# Altium schematic sheet LED Driver.SchDoc("LED Driver")
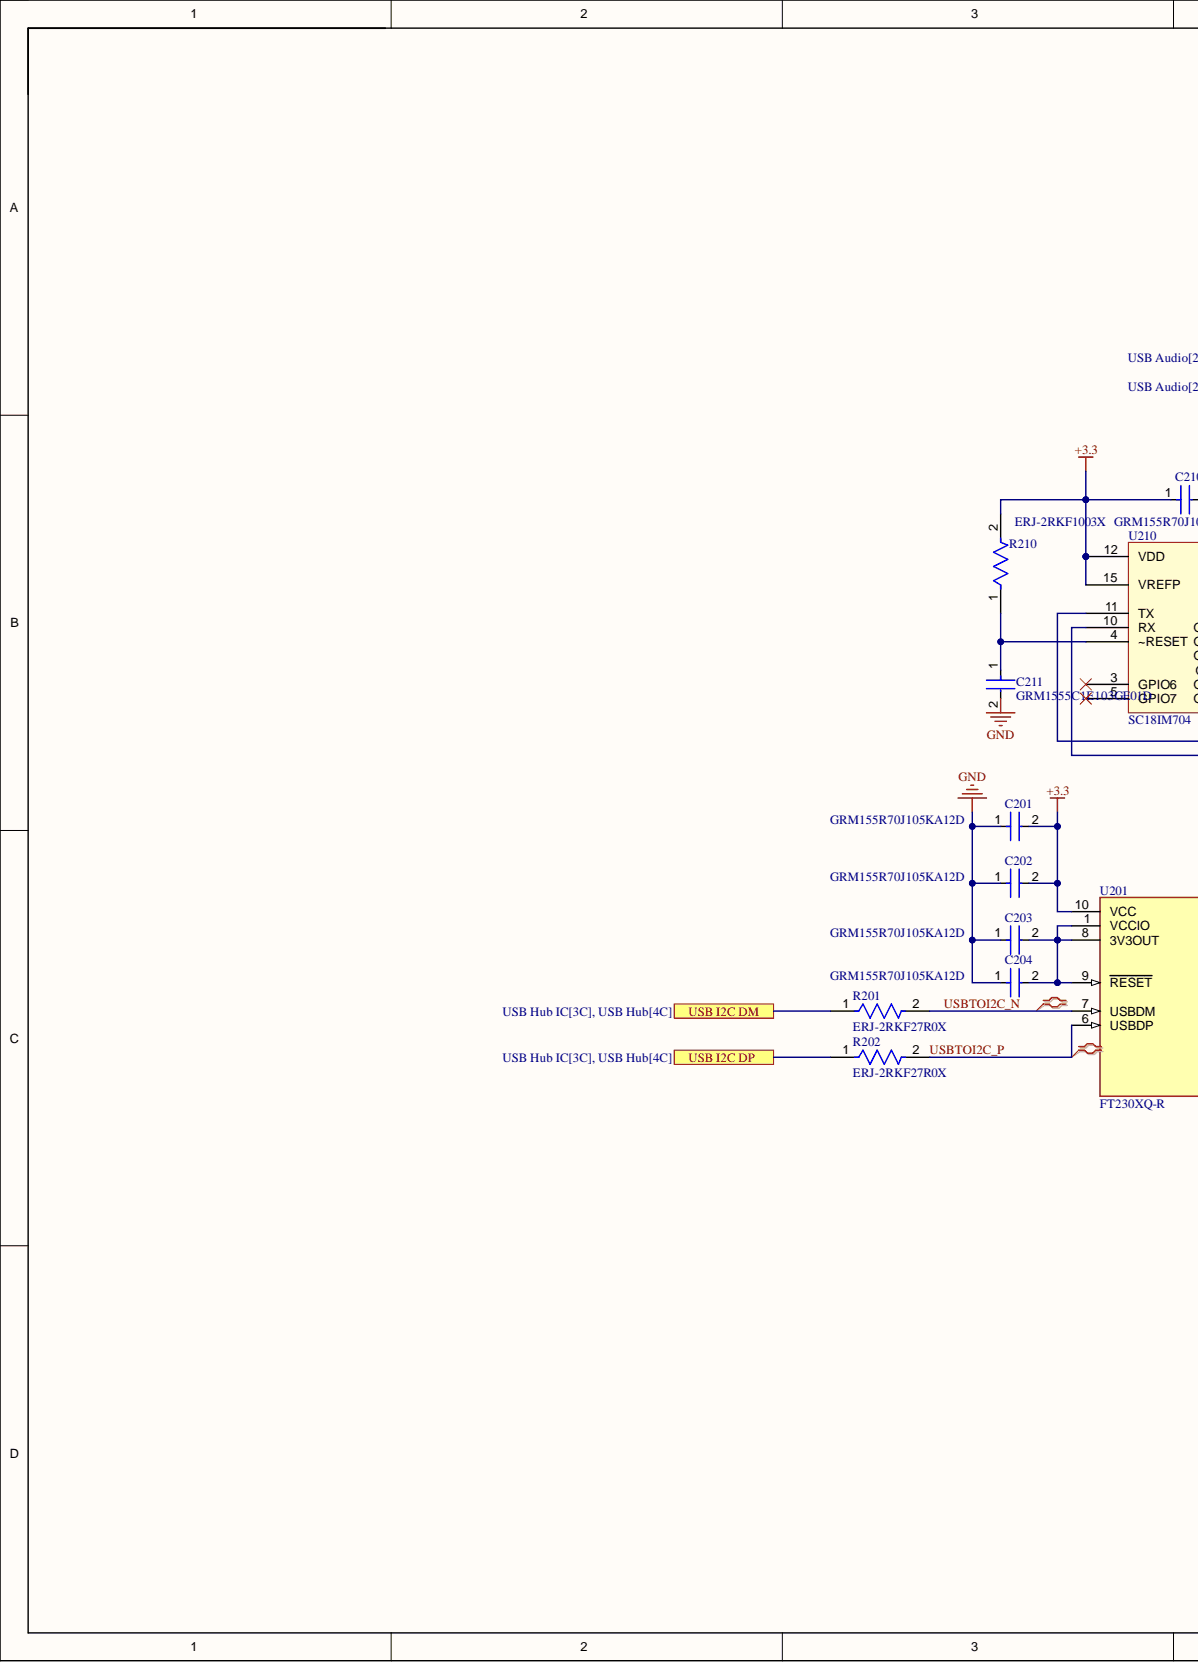
[diagram p0: LED Driver.SchDoc, left]
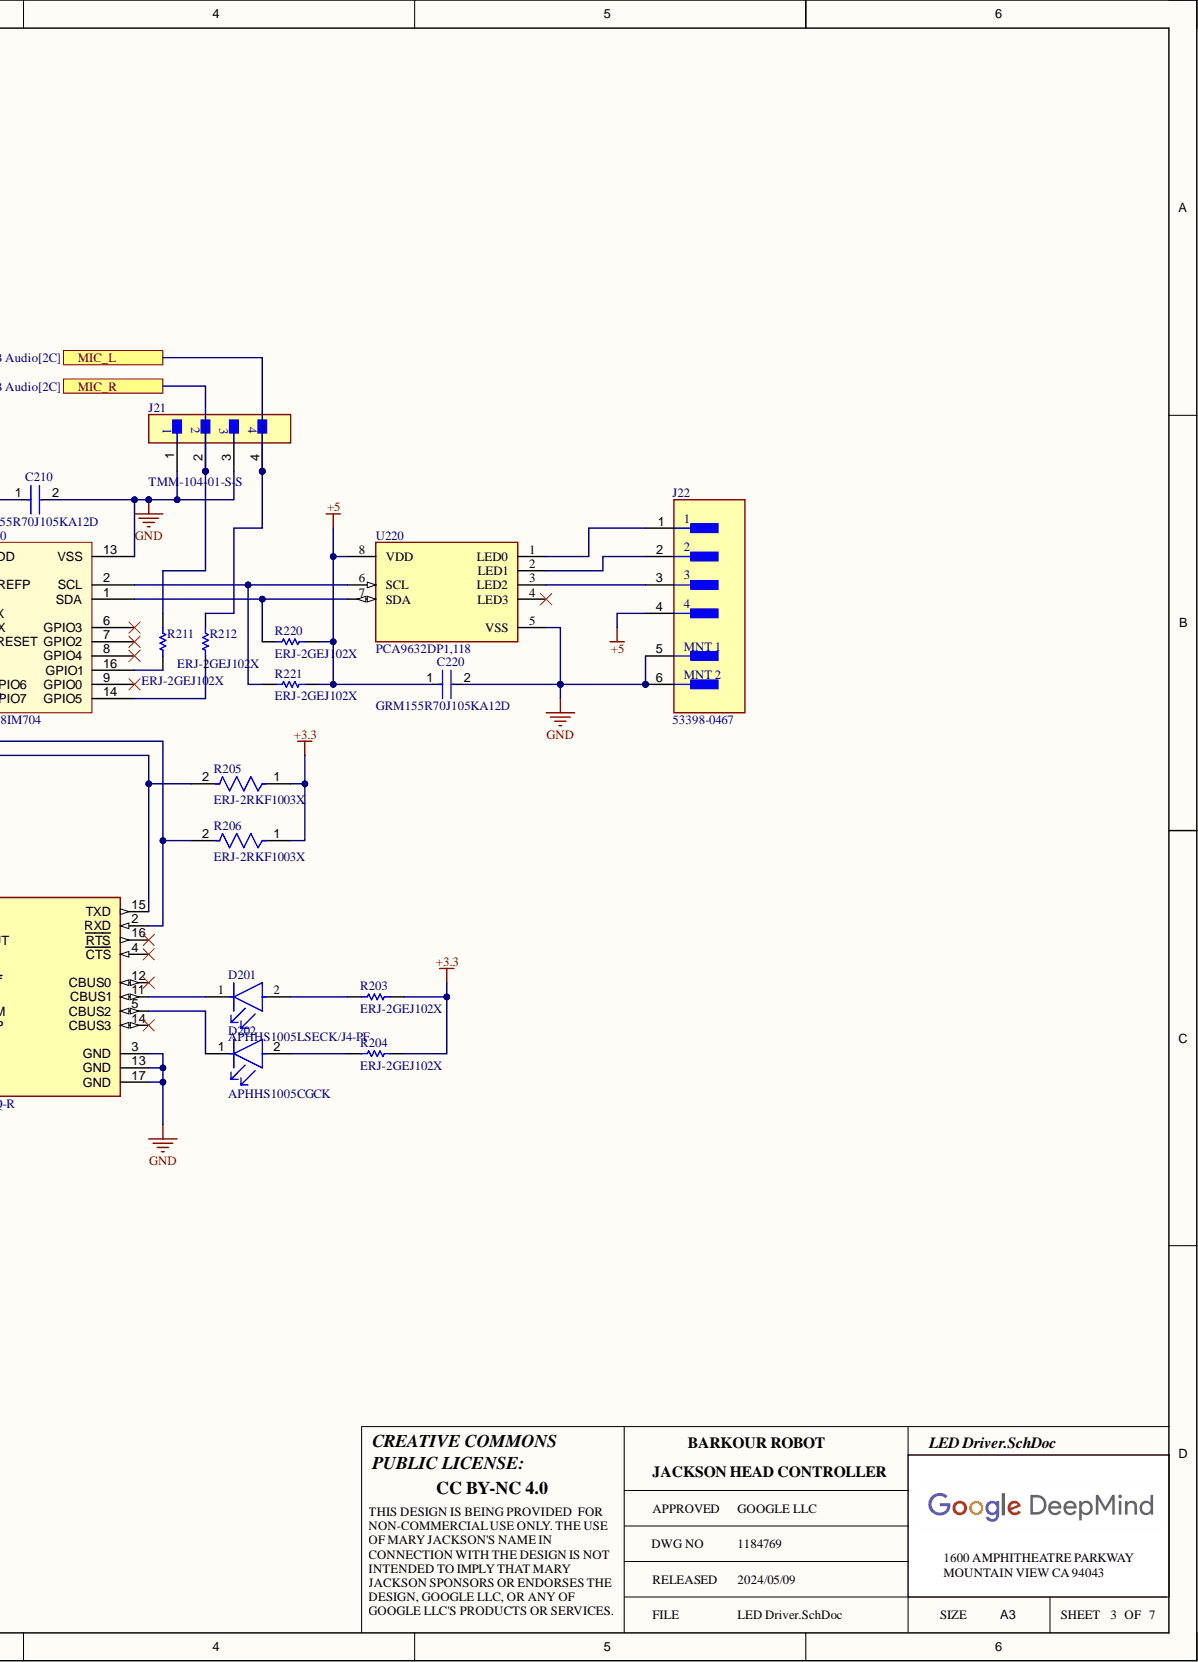
[diagram p1: LED Driver.SchDoc, right]

## Parts
C201  GRM155R70J105KA12D  [FP-GRM155-0_05-MFG]
C202  GRM155R70J105KA12D  [FP-GRM155-0_05-MFG]
C203  GRM155R70J105KA12D  [FP-GRM155-0_05-MFG]
C204  GRM155R70J105KA12D  [FP-GRM155-0_05-MFG]
C210  GRM155R70J105KA12D  [FP-GRM155-0_05-MFG]
C211  GRM1555C1E103GE01D  [FP-GRM155-0_15-IPC_B]
C220  GRM155R70J105KA12D  [FP-GRM155-0_05-MFG]
D201  APHHS1005LSECK/J4-PF  [FP-APHHS1005LSECK-J4-PF-MFG]
D202  APHHS1005CGCK  [FP-APHHS1005CGCK-MFG]
J21  TMM-104-01-S-S  [FP-TMM-104-01-S-S-MFG]
J22  53398-0467  [FP-53398-0467-MFG]
R201  ERJ-2RKF27R0X  [FP-ERJ2R-IPC_B]
R202  ERJ-2RKF27R0X  [FP-ERJ2R-IPC_B]
R203  ERJ-2GEJ102X  [RESC1005X40X25NL05T05]
R204  ERJ-2GEJ102X  [RESC1005X40X25NL05T05]
R205  ERJ-2RKF1003X  [FP-ERJ2RK-IPC_C]
R206  ERJ-2RKF1003X  [FP-ERJ2RK-IPC_C]
R210  ERJ-2RKF1003X  [FP-ERJ2RK-IPC_C]
R211  ERJ-2GEJ102X  [RESC1005X40X25NL05T05]
R212  ERJ-2GEJ102X  [RESC1005X40X25NL05T05]
R220  ERJ-2GEJ102X  [RESC1005X40X25NL05T05]
R221  ERJ-2GEJ102X  [RESC1005X40X25NL05T05]
U201  FT230XQ-R  [FP-QFN-16-MFG]
U210  SC18IM704  [TSSOP-16]
U220  PCA9632DP1,118  [FP-SOT505-1-IPC_C]

## Ports
MIC_L, MIC_R, USB I2C DM, USB I2C DP

## Nets
+3.3: C201.2 C202.2 C210.1 R203.2 R204.2 R205.1 R206.1 R210.2 U201.10(VCC) U210.12(VDD) U210.15(VREFP)
+5: C220.1 J22.4 R220.2 R221.2 U220.8(VDD)
GND: C201.1 C202.1 C203.1 C204.1 C210.2 C211.2 C220.2 J21.1 J21.3 J22.5 J22.6 U201.3(GND) U201.13(GND) U201.17(GND) U210.13(VSS) U220.5(VSS)
MIC_L: J21.4 R212.2 ; port MIC_L
MIC_R: J21.2 R211.2 ; port MIC_R
USB I2C DM: R201.1 ; port USB I2C DM
USB I2C DP: R202.1 ; port USB I2C DP
USBTOI2C_N: R201.2 U201.7(USBDM)
USBTOI2C_P: R202.2 U201.6(USBDP)
NetC203_2: C203.2 C204.2 U201.1(VCCIO) U201.8(3V3OUT) U201.9(RESET)
NetC211_1: C211.1 R210.1 U210.4(~RESET)
NetD201_1: D201.1 U201.11(CBUS1)
NetD201_2: D201.2 R203.1
NetD202_1: D202.1 U201.5(CBUS2)
NetD202_2: D202.2 R204.1
NetJ22_1: J22.1 U220.1(LED0)
NetJ22_2: J22.2 U220.2(LED1)
NetJ22_3: J22.3 U220.3(LED2)
NetR205_2: R205.2 U201.15(TXD) U210.10(RX)
NetR206_2: R206.2 U201.2(RXD) U210.11(TX)
NetR211_1: R211.1 U210.16(GPIO1)
NetR212_1: R212.1 U210.14(GPIO5)
NetR220_1: R220.1 U210.1(SDA) U220.7(SDA)
NetR221_1: R221.1 U210.2(SCL) U220.6(SCL)

Not connected: U201 4(CTS) 12(CBUS0) 14(CBUS3) 16(RTS); U210 3(GPIO6) 5(GPIO7) 6(GPIO3) 7(GPIO2) 8(GPIO4) 9(GPIO0); U220 4(LED3)

## Library data of the parts
C201-C204 C210 C220 = CMP-2008-04587-2: Chip Multilayer Ceramic Capacitors for General Purpose, 0402, 1.0uF, X7R, 15%, 10%, 6.3V; Capacitance: 1uF; Case Code (Imperial): 0402; Case Code (Metric): 1005; Case/Package: 0402; Component Kind: Standard; Depth: 0.5mm; Dielectric: X7R; Height: 0.5mm; Lead Free: Lead Free; Length: 1mm; Library Name: Altium Content Vault; Material: Ceramic; Max Operating Temperature: 125°C; Min Operating Temperature: -55°C; Mount: Surface Mount; Package Quantity: 10000; Packaging: Tape and Reel; Termination: SMD/SMT; Thickness: 0.022inch; Tolerance: 10%; Voltage Rating: 6.3V; Voltage Rating (DC): 6.3V; Weight: 0.000212oz; Width: 0.5mm
C211 = CMP-06035-007392-1: Chip Multilayer Ceramic Capacitors for General Purpose, 0402, 10000pF, C0G, 30ppm/°C, 2%, 25V; Component Kind: Standard; Library Name: Altium Content Vault
D201 = CMP-00682-82290958-1: APHHS1005LSECK/J4-PF LED Uni-Color SB. Orange 611nm 2-Pin SMD T/R; Forward Current: 2mA; Forward Voltage: 1.8V; Luminous Intensity: 40mcd; Max Junction Temperature (Tj): 115°C; Power Dissipation: 84mW; Reverse Voltage: 5V; Viewing Angle: 120°; Wavelength: 605nm; Case/Package: 0402; Color: Orange; Component Kind: Standard; Height: 600µm; Library Name: Altium Content Vault; Max Operating Temperature: 85°C; Min Operating Temperature: -40°C; NexarPartId: 82290958; RoHS: Non-Compliant; Schedule B: 8541402000, 8541402000
D202 = CMP-44529-000044-1: LED GREEN CLEAR CHIP SMD; Dominant Wavelength: 570nm; Forward Current: 20mA; Forward Voltage: 2.1V; Luminous Intensity: 50mcd; Number of LEDs: 1; Viewing Angle: 120°; Case Code (Imperial): 0402; Case Code (Metric): 1005; Case/Package: 0402; Color: Green; Component Kind: Standard; Height: 0.6mm; Illumination Color: Green; Lead Free: Lead Free; Length: 1mm; Lens Color: Clear; Lens Style: Clear; Library Name: Altium Content Vault; Max Operating Temperature: 85°C; Min Operating Temperature: -40°C; Mount: Surface Mount; Number of Pins: 2; Package Quantity: 2000; Packaging: Tape and Reel; Test Current: 20mA; Wavelength: 570nm; Width: 0.5mm
J21 = CMP-02766-001674-1: CONN HEADER VERT 4POS 2MM; Connector Type: Male Pin; Gender: Male; Max Current Rating: 3.9A; Max Voltage Rating (AC): 500V; Number of Contacts: 4; Color: Black; Component Kind: Standard; Contact Material: Bronze; Contact Mating Length: 0.126inch; Contact Plating: Gold; Contact Type: Male Pin; Depth: 1.97mm; Housing Color: Black; Housing Material: Polymer; Lead Free: Lead Free; Length: 8mm; Library Name: Altium Content Vault; Max Operating Temperature: 125°C; Min Operating Temperature: -55°C; Mount: Through Hole; Number of Positions: 4; Number of Rows: 1; Orientation: Straight; Package Quantity: 1; Packaging: Bulk; Pitch: 2mm; Radiation Hardening: No; RoHS Compliant: Yes; Series: FleXYZ™ TMM; Termination: Solder; Width: 1.97mm
J22 = CMP-04776-000301-1: CONN HEADER SMD 4POS 1.25MM; Contact Resistance: 20mR; Current Rating: 1A; Max Voltage Rating (AC): 125V; Number of Contacts: 4; Component Kind: Standard; Contact Gender: Male; Contact Material: Bronze; Contact Plating: Tin; Housing Material: Nylon; Library Name: Altium Content Vault; Max Operating Temperature: 85°C; Min Operating Temperature: -40°C; Mount: Surface Mount; Number of Positions: 4; Number of Rows: 1; Orientation: Straight; Packaging: Tape and Reel; Pitch: 1.25mm; Radiation Hardening: No; RoHS Compliant: Yes; Termination: Solder; Voltage Rating: 125V
R201 R202 = CMP-2002-00830-2: RES SMD 27 OHM 1% 1/10W 0402; Max Power Dissipation: 100mW; Resistance: 27R; Temperature Coefficient: 100ppm/°C; Voltage Rating: 50V; Case Code (Imperial): 0402; Case Code (Metric): 1005; Case/Package: 0402; Component Kind: Standard; Construction: Rectangular; Contact Plating: Tin; Depth: 0.5mm; Features: Automotive AEC-Q200; Height: 0.35mm; Lead Free: Lead Free; Length: 1mm; Library Name: Altium Content Vault; Max Operating Temperature: 155°C; Military Standard: Not; Min Operating Temperature: -55°C; Mount: Surface Mount; Number of Pins: 2; Number of Terminations: 2; Package Quantity: 1; Packaging: Tape and Reel; Power Rating: 100mW; Radiation Hardening: No; REACH SVHC: No SVHC; Resistance Tolerance: 1%; Resistor Type: Thick Film; RoHS Compliant: Yes; Series: ERJ; Technology: THICK FILM; Termination: SMD/SMT; Tolerance: 1%; Voltage Rating (DC): 50V; Weight: 2.8E-05oz; Width: 0.5mm
R203 R204 R211 R212 R220 R221 = CMP-2002-00432-1: Manufacturer: Panasonic; Component Kind: Standard; Library Name: Altium Content Vault; Part Number: ERJ-2GEJ102X
R205 R206 R210 = CMP-2002-00608-4: RES SMD 100K OHM 1% 1/10W 0402; Max Power Dissipation: 100mW; Resistance: 100kR; Temperature Coefficient: 100ppm/°C; Voltage Rating: 50V; Case Code (Imperial): 0402; Case Code (Metric): 1005; Case/Package: 0402; Component Kind: Standard; Composition: Thick Film; Contact Plating: Tin; Depth: 0.5mm; Features: Automotive AEC-Q200; Height: 0.35mm; Lead Free: Lead Free; Length: 1mm; Library Name: Altium Content Vault; Max Operating Temperature: 155°C; Military Standard: Not; Min Operating Temperature: -55°C; Mount: Surface Mount; Number of Pins: 2; Number of Terminations: 2; Package Quantity: 1; Packaging: Tape and Reel; Power Rating: 100mW; Radiation Hardening: No; REACH SVHC: No SVHC; Termination: SMD/SMT; Tolerance: 1%; Voltage Rating (DC): 50V; Width: 0.5mm
U201 = CMP-0248-00010-3: IC USB SERIAL BASIC UART 16QFN; Data Rate: 12Mbps; Max Supply Voltage: 5.5V; Min Supply Voltage: 2.97V; Number of Channels: 1; Operating Supply Current: 8mA; Operating Supply Voltage: 5V; Case/Package: QFN; Component Kind: Standard; Height: 0.75mm; Interface: USB; Lead Free: Lead Free; Length: 4mm; Library Name: Altium Content Vault; Max Operating Temperature: 85°C; Min Operating Temperature: -40°C; Mount: Surface Mount; Number of Pins: 16; Package Quantity: 5000; Packaging: Tape and Reel; Weight: 0.002014oz; Width: 4mm
U220 = CMP-10140-000008-1: IC LED DRVR LIN DIM 25MA 8TSSOP; Frequency: 400kHz; Low Level Output Current: 100mA; Max Power Dissipation: 400mW; Max Supply Current: 150uA; Max Supply Voltage: 5.5V; Min Supply Voltage: 2.3V; Number of Outputs: 4; Case/Package: TSSOP; Component Kind: Standard; Lead Free: Lead Free; Library Name: Altium Content Vault; Max Operating Temperature: 85°C; Min Operating Temperature: -40°C; Mount: Surface Mount; Nominal Supply Current: 150uA; Number of Pins: 8; Number of Segments: 4; Output Voltage: 5.5V; Package Quantity: 1; Packaging: Tape and Reel; Power Dissipation: 400mW; Radiation Hardening: No; RoHS Compliant: Yes; Series: -; Supply Current: 150uA
U210 = SC18IM704: Component Kind: Standard; Library Name: Schlib1.SchLib
